# Altium schematic sheet Sheet1.SchDoc(Sheet1)
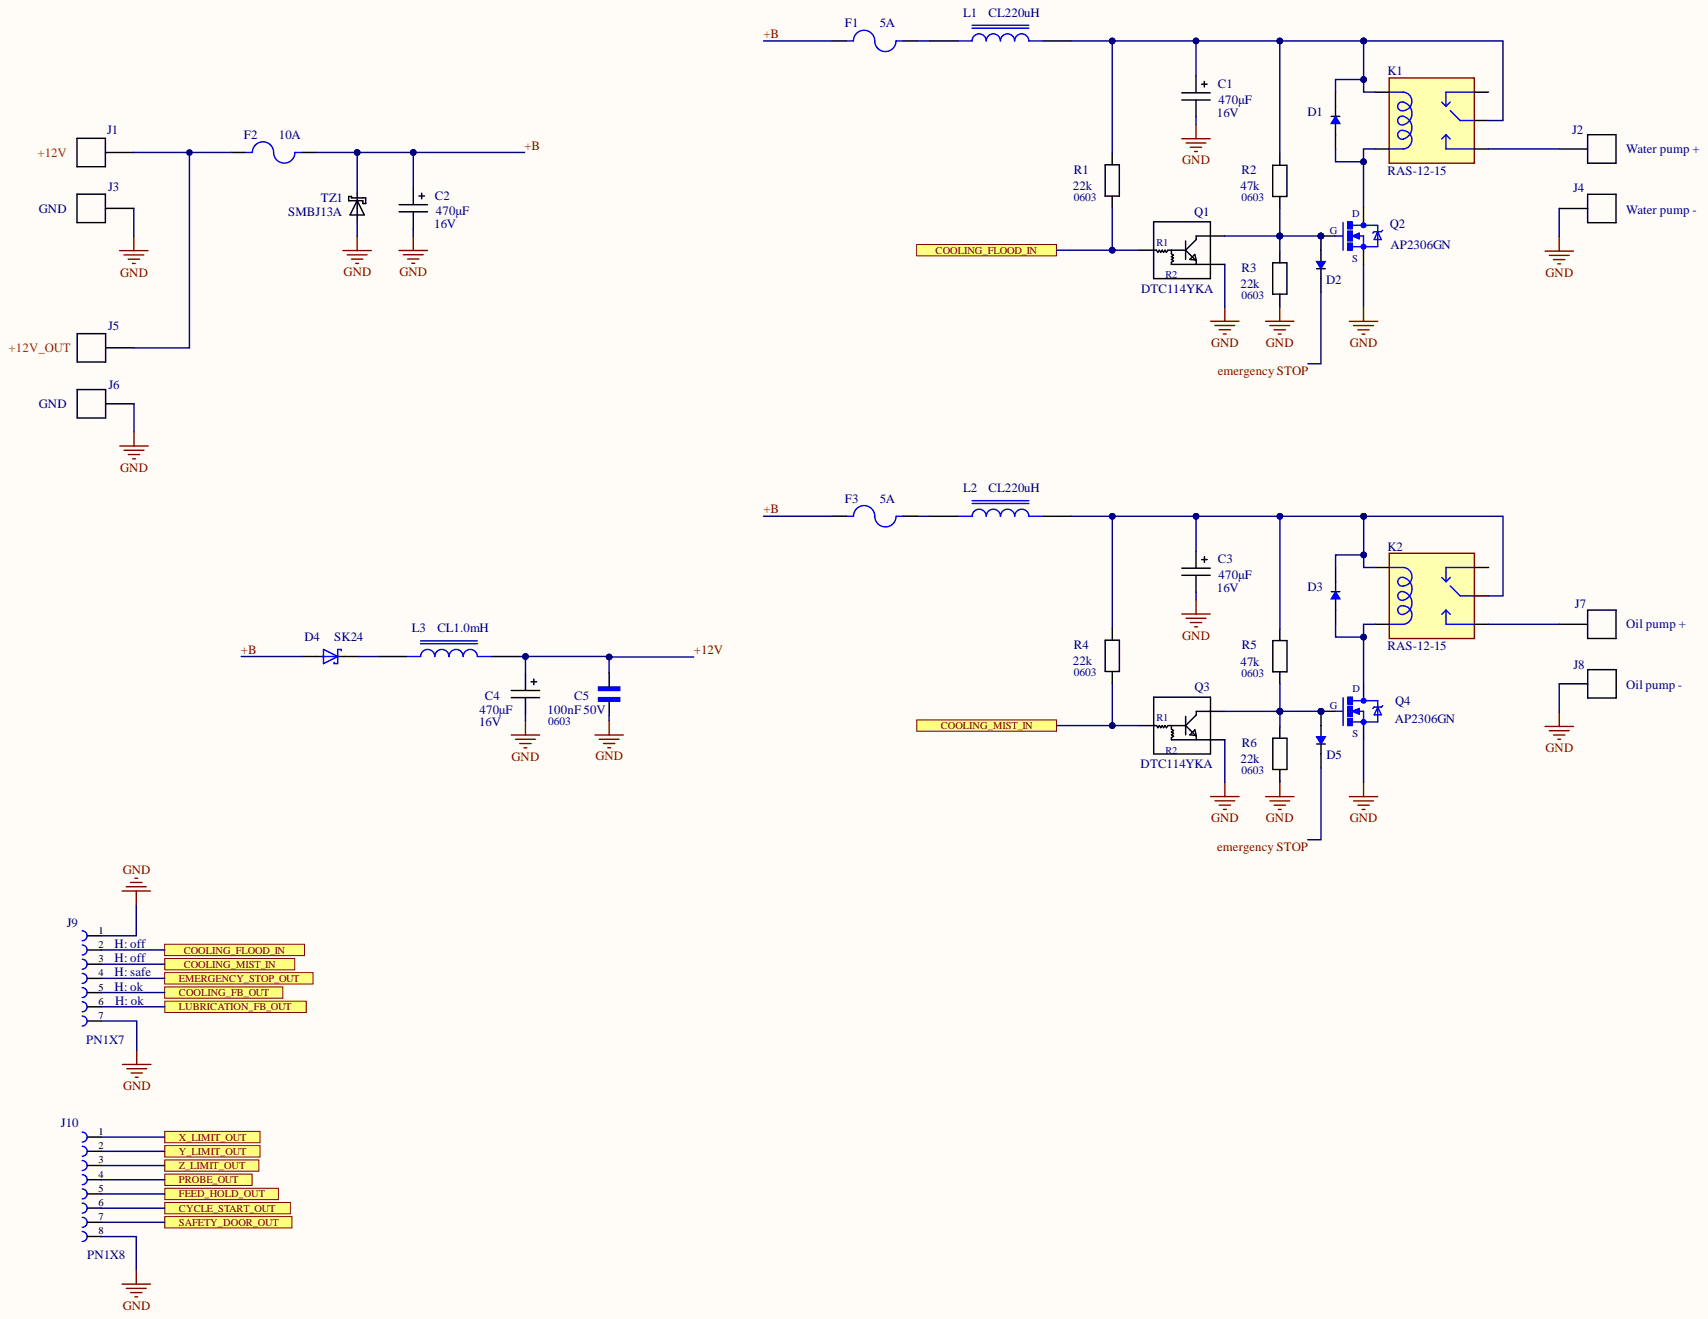
[diagram p0: Sheet1.SchDoc]

## Parts
C1  470μF  Voltage: 16V  [CAP 8x12]
C2  470μF  Voltage: 16V  [CAP 8x12]
C3  470μF  Voltage: 16V  [CAP 8x12]
C4  470μF  Voltage: 16V  [CAP 8x12]
C5  100nF 50V  [SMD 0603 CAP]
D1  1N4148W  [SOD123]  (value not drawn)
D2  1N4148W  [SOD123]  (value not drawn)
D3  1N4148W  [SOD123]  (value not drawn)
D4  SK24  [SMB]
D5  1N4148W  [SOD123]  (value not drawn)
F1  5A  [FUSE HOLDER]
F2  10A  [FUSE HOLDER]
F3  5A  [FUSE HOLDER]
J1  +12V  [Wire-2]
J2  Water pump +  [Wire-2]
J3  GND  [Wire-2]
J4  Water pump -  [Wire-2]
J5  +12V_OUT  [Wire-2]
J6  GND  [Wire-2]
J7  Oil pump +  [Wire-2]
J8  Oil pump -  [Wire-2]
J9  PN1X7  [PN1X7]
J10  PN1X8  [PN1X8]
K1  RAS-12-15  [RELAY RAS-12-15 (SPDT)]
K2  RAS-12-15  [RELAY RAS-12-15 (SPDT)]
L1  CL220uH  [INDC RBQE]
L2  CL220uH  [INDC RBQE]
L3  CL1.0mH  [DRH104R]
Q1  DTC114YKA  [SOT-346 (SC59) Black]
Q2  AP2306GN  [SOT-23-3]
Q3  DTC114YKA  [SOT-346 (SC59) Black]
Q4  AP2306GN  [SOT-23-3]
R1  22k  Case: 0603  [SMD 0603]
R2  47k  Case: 0603  [SMD 0603]
R3  22k  Case: 0603  [SMD 0603]
R4  22k  Case: 0603  [SMD 0603]
R5  47k  Case: 0603  [SMD 0603]
R6  22k  Case: 0603  [SMD 0603]
TZ1  SMBJ13A  [DO-214AA (SMB)]

## Ports
COOLING_FB_OUT, COOLING_FLOOD_IN, COOLING_MIST_IN, CYCLE_START_OUT, EMERGENCY_STOP_OUT, FEED_HOLD_OUT, LUBRICATION_FB_OUT, PROBE_OUT, SAFETY_DOOR_OUT, X_LIMIT_OUT, Y_LIMIT_OUT, Z_LIMIT_OUT

## Nets
GND: C1.2 C2.2 C3.2 C4.2 C5.1 J3.1 J4.1 J6.1 J8.1 J9.1 J9.7 J10.8 Q1.2 Q2.2 Q3.2 Q4.2 R3.2 R6.2 TZ1.A
+12V: C4.1 C5.2 L3.2
+B: C2.1 D4.A F1.1 F2.2 F3.1 TZ1.C
COOLING_FB_OUT: J9.5 ; port COOLING_FB_OUT
COOLING_FLOOD_IN: J9.2 Q1.1 R1.2 ; port COOLING_FLOOD_IN
COOLING_MIST_IN: J9.3 Q3.1 R4.2 ; port COOLING_MIST_IN
CYCLE_START_OUT: J10.6 ; port CYCLE_START_OUT
emergency STOP: D2.C D5.C
EMERGENCY_STOP_OUT: J9.4 ; port EMERGENCY_STOP_OUT
FEED_HOLD_OUT: J10.5 ; port FEED_HOLD_OUT
LUBRICATION_FB_OUT: J9.6 ; port LUBRICATION_FB_OUT
PROBE_OUT: J10.4 ; port PROBE_OUT
SAFETY_DOOR_OUT: J10.7 ; port SAFETY_DOOR_OUT
X_LIMIT_OUT: J10.1 ; port X_LIMIT_OUT
Y_LIMIT_OUT: J10.2 ; port Y_LIMIT_OUT
Z_LIMIT_OUT: J10.3 ; port Z_LIMIT_OUT
NetC1_1: C1.1 D1.C K1.1 K1.C L1.2 R1.1 R2.1
NetC3_1: C3.1 D3.C K2.1 K2.C L2.2 R4.1 R5.1
NetD1_A: D1.A K1.2 Q2.3
NetD2_A: D2.A Q1.3 Q2.1 R2.2 R3.1
NetD3_A: D3.A K2.2 Q4.3
NetD4_C: D4.C L3.1
NetD5_A: D5.A Q3.3 Q4.1 R5.2 R6.1
NetF1_2: F1.2 L1.1
NetF2_1: F2.1 J1.1 J5.1
NetF3_2: F3.2 L2.1
NetJ2_1: J2.1 K1.NO
NetJ7_1: J7.1 K2.NO

Not connected: K1 NC; K2 NC

## Library data of the parts
C1-C4 = CAP Aluminium: Electrolytic capacitor, Long Life 8000h/105C, Low impedance, 470uF/16V 20%, 8.0x11.5mm, RM3.5mm; Manufacturer: RUBYCON CORP; Tipe: Low ESR; Case: 8x11,5x3,5; Component Kind: Standard; HelpURL: https://store.comet.bg/Catalogue/Product/5165584/; Library Name: CAN_Library.IntLib; Temperature: -55...+105°C; Value: 470μF
C5 = CL32B106KLJNNNE: 100nF 50V X7R +-10%; Manufacturer: SAMSUNG ELECTRO-MECHANICS; Case: 0603; Component Kind: Standard; HelpURL: https://store.comet.bg/Catalogue/Product/5963/; Library Name: CAN_Library.IntLib; Model: CL32B106KLJNNNE; Value: 100nF 50V
D1-D3 D5 = 1N4148W: Diode Standard 100V 300mA _DC_ Surface Mount SOD-123; MF: DIODES / ZETEX; MP: 1N4148W-7-F; Case: SOD-123 Diodes Inc.; Component Kind: Standard; HelpURL: https://store.comet.bg/Catalogue/Product/9613/; Library Name: CAN_Library.IntLib; Value: 1N4148W
D4 = SK24: Diode 40V 2A UFM0.55V/2A IFM 50A IR 0.45mA, Schottky; MF: SM TECHNOLOGY; Case: SMB; Component Kind: Standard; Library Name: CAN_Library.IntLib; Value: SK24
F1-F3 = Fuse: Fuse Holder, 250 V, 6 A, Pcb Fuse Holder, Through Hole, P22,4mm; Case: FH-1099; Component Kind: Standard; Library Name: CAN_Library.IntLib; URL: https://store.comet.bg/Catalogue/Product/51164/
  F1 F3: Value: 5A
  F2: Value: 10A
J1-J8 = Wire: Case: 0,8mm; Component Kind: Standard; Description: Wire; Library Name: CAN_Library.IntLib; Value: Wire
J9 = PN1X7: Single Row / Straight / Without Shroud Female header Female 7 | WÃ¼rth Elektronik 61300711821 @ Pin header, socket _Si...; Case: 2,54 mm; Component Kind: Standard; Library Name: CAN_Library.IntLib; Value: PN1X7
J10 = PN1X8: Single Row / Straight / Without Shroud Female header Female 8 | WÃ¼rth Elektronik 61300711821 @ Pin header, socket _Si...; Case: 2,54 mm; Component Kind: Standard; Library Name: CAN_Library.IntLib; Value: PN1X8
K1 K2 = RAS-12-15: RELAY 12V /30mA 15A/24VDC(120VAC) 10A/250VAC; MF: SUN HOLD; Component Kind: Standard; Library Name: CAN_Library.IntLib; Package: SPDT; Purchase-URL: https://store.comet.bg/Catalogue/Product/23849/; Value: RAS-12-15
L1 L2 = DRH104R: Toroidal Coil 220uH 0.099 ohm 3000mA 20%; MF: COILS; MP: RBQE845744NP; Case: RBQE; Component Kind: Standard; Library Name: CAN_Library.IntLib; Value: CL220uH
L3 = DRH104R: CL1.0mH SDI104R (DRH104R);     2.959 ohm, 0.48A, 20%; MF: CORE MASTER; MP: SDI 104R - 102M; Case: DRH104R; Component Kind: Standard; Library Name: CAN_Library.IntLib; Value: CL1.0mH
Q1 Q3 = DTC114YKA: NPN Digital 50V 100mA 200mW  R1=10kOm R2=47kOm; Manufacturer: ROHM Semiconductor; Case: SOT-346 (SC59); Component Kind: Standard; HalpURL: https://store.comet.bg/Catalogue/Product/23715/; Library Name: CAN_Library.IntLib; Value: DTC114YKA
Q2 Q4 = AP2306GN: NMOS 20V 5.3A 1.38W 35mOhm/4.5V; 50mOhm/2.5V; MF: ADVANCED POWER ELECTR. CO; MP: AP2306GN-HF-3TR; Component Kind: Standard; Library Name: CAN_Library.IntLib; Package: SOT-23; Value: AP2306GN
R1-R6 = Resistor_2: RES; Component Kind: Standard; HelpURL: https://store.comet.bg/Catalogue/RES/Resistors/#eyJpcHAiOiIxMDAiLCJtIjoiIn0%3D; Library Name: CAN_Library.IntLib
  R1 R3 R4 R6: Value: 22k
  R2 R5: Value: 47k
TZ1 = SMBJ18A: Transient Voltage Suppressors, Uni-directional, 600W, UBRmin14.4V@1mA; Manufacturer: Vishay General Semiconductor; Case: DO-214AA SMB; Component Kind: Standard; HelpURL: https://store.comet.bg/Catalogue/Product/9448/; Library Name: CAN_Library.IntLib; Value: SMBJ13A
